# Altium schematic sheet Sheet2.SchDoc
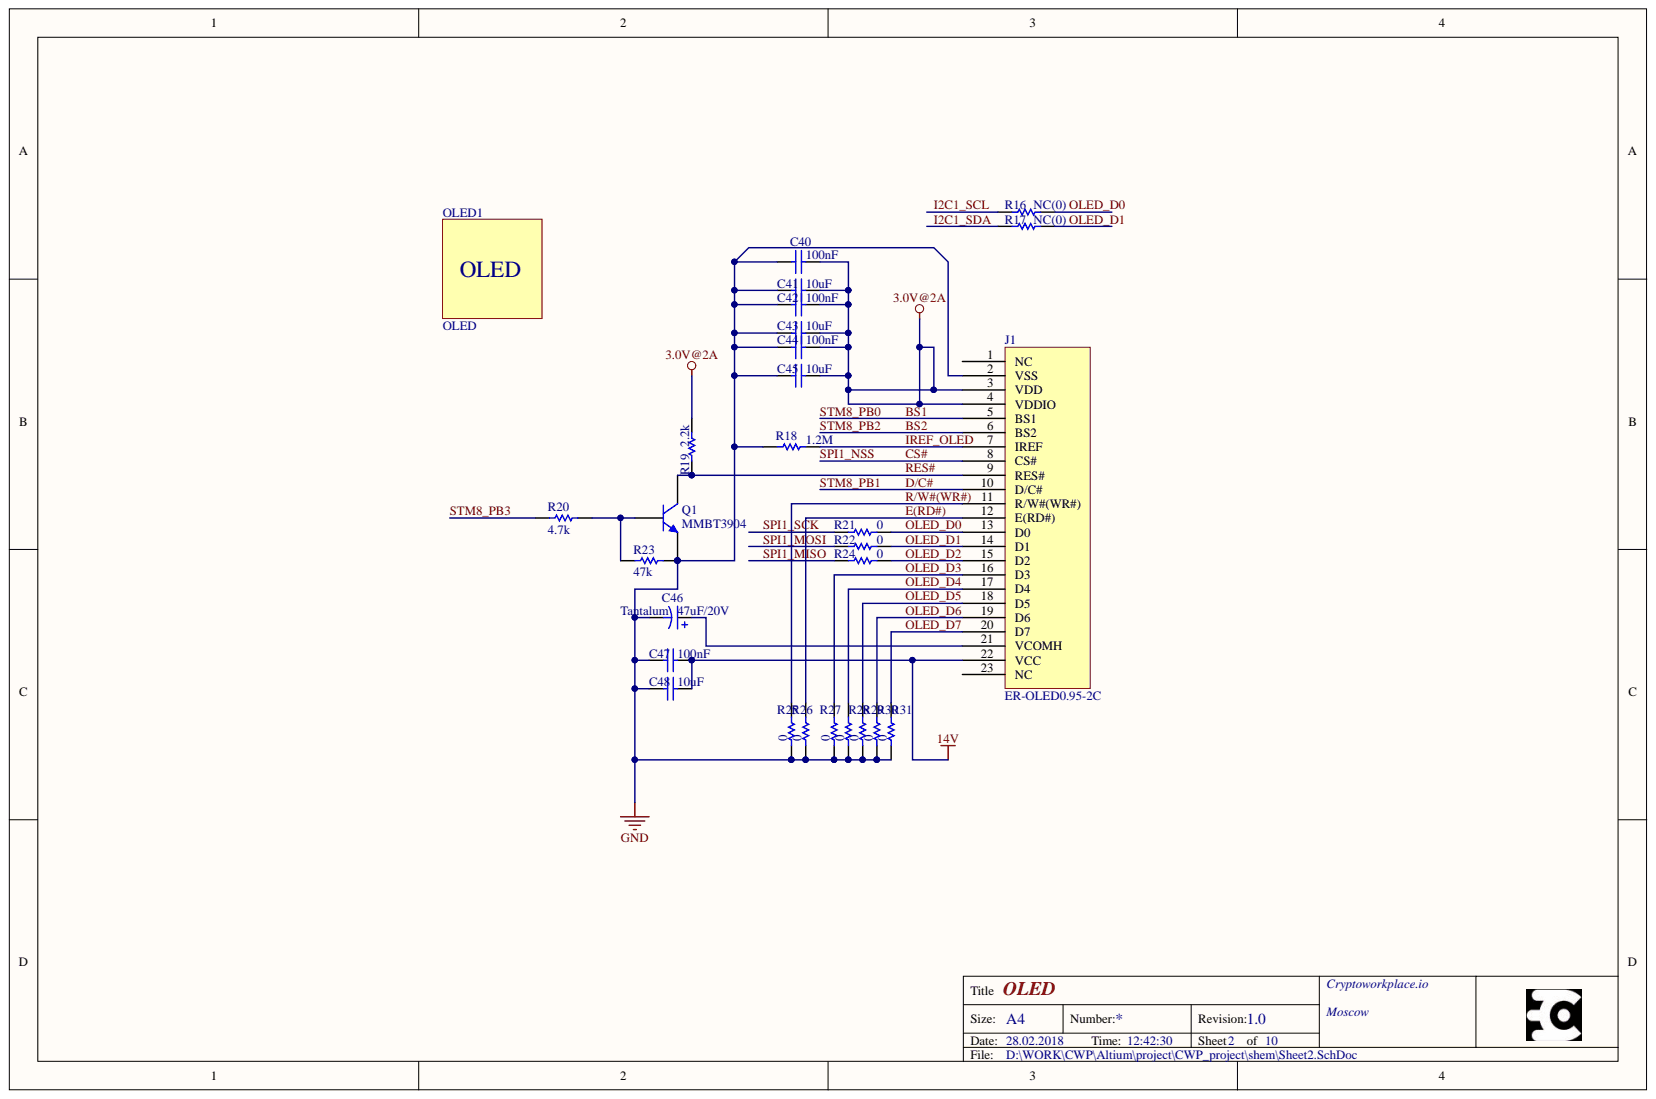
[diagram p0: Sheet2.SchDoc]

## Parts
C40  value 100nF  [0402(CAPC1005X06N)]  (hidden comment: 0402)
C41  value 10uF  [0603(CAPC1608X09N)]  (hidden comment: Cap)
C42  value 100nF  [0402(CAPC1005X06N)]  (hidden comment: 0402)
C43  value 10uF  [0603(CAPC1608X09N)]  (hidden comment: Cap)
C44  value 100nF  [0402(CAPC1005X06N)]  (hidden comment: 0402)
C45  value 10uF  [0603(CAPC1608X09N)]  (hidden comment: Cap)
C46  Tantalum  value 47uF/20V  [SMDDCAP]
C47  value 100nF  [0402(CAPC1005X06N)]  (hidden comment: 0402)
C48  value 10uF  [0603(CAPC1608X09N)]  (hidden comment: Cap)
J1  ER-OLED0.95-2C  [oled 23 pin con]
OLED1  OLED  [oled 0.96]
Q1  MMBT3904  [MMBT3904]
R16  value NC(0)  [0402(RESC1005X04N)]  (hidden comment: Res1)
R17  value NC(0)  [0402(RESC1005X04N)]  (hidden comment: Res1)
R18  value 1.2M  [0402(RESC1005X04N)]  (hidden comment: Res1)
R19  value 2.2k  [0402(RESC1005X04N)]  (hidden comment: Res1)
R20  value 4.7k  [0402(RESC1005X04N)]  (hidden comment: Res1)
R21  value 0  [0402(RESC1005X04N)]  (hidden comment: Res1)
R22  value 0  [0402(RESC1005X04N)]  (hidden comment: Res1)
R23  value 47k  [0402(RESC1005X04N)]  (hidden comment: Res1)
R24  value 0  [0402(RESC1005X04N)]  (hidden comment: Res1)
R25  value 0  [0402(RESC1005X04N)]  (hidden comment: Res1)
R26  value 0  [0402(RESC1005X04N)]  (hidden comment: Res1)
R27  value 0  [0402(RESC1005X04N)]  (hidden comment: Res1)
R28  value 0  [0402(RESC1005X04N)]  (hidden comment: Res1)
R29  value 0  [0402(RESC1005X04N)]  (hidden comment: Res1)
R30  value 0  [0402(RESC1005X04N)]  (hidden comment: Res1)
R31  value 0  [0402(RESC1005X04N)]  (hidden comment: Res1)

## Nets
3.0V@2A: C40.1 C41.1 C42.1 C43.1 C44.1 C45.1 J1.3(VDD) J1.4(VDDIO) R19.2
14V: C47.1 C48.1 J1.22(VCC)
GND: C40.2 C41.2 C42.2 C43.2 C44.2 C45.2 C46.2 C47.2 C48.2 J1.2(VSS) Q1.3 R18.1 R23.2 R25.2 R26.2 R27.2 R28.2 R29.2 R30.2 R31.2
BS1: J1.5(BS1)
BS2: J1.6(BS2)
CS#: J1.8(CS#)
D/C#: J1.10(D/C#)
E(RD#): J1.12(E(RD#)) R26.1
I2C1_SCL: R16.2
I2C1_SDA: R17.2
IREF_OLED: J1.7(IREF) R18.2
OLED_D0: J1.13(D0) R16.1 R21.2
OLED_D1: J1.14(D1) R17.1 R22.2
OLED_D2: J1.15(D2) R24.2
OLED_D3: J1.16(D3) R27.1
OLED_D4: J1.17(D4) R28.1
OLED_D5: J1.18(D5) R29.1
OLED_D6: J1.19(D6) R30.1
OLED_D7: J1.20(D7) R31.1
R/W#(WR#): J1.11(R/W#(WR#)) R25.1
RES#: J1.9(RES#) Q1.1 R19.1
SPI1_MISO: R24.1
SPI1_MOSI: R22.1
SPI1_SCK: R21.1
STM8_PB3: R20.1
NetC46_1: C46.1 J1.21(VCOMH)
NetQ1_2: Q1.2 R20.2 R23.1

Not connected: J1 1(NC) 23(NC)

## Library data of the parts
C40-C45 C47 C48 = Cap: Capacitor; Component Kind: Standard; LatestRevisionDate: 17-Jul-2002; LatestRevisionNote: Re-released for DXP Platform.; Library Name: Miscellaneous Devices.IntLib; PackageDescription: Radial Cap, Thru-Hole; 2 Leads; 0.3 in Pin Spacing; PackageReference: RAD-0.3; Published: 8-Jun-2000; Signal Integrity: Cap; Simulation: CAP; Publisher: Altium Limited
C46 = Cap Pol1: Polarized Capacitor (Radial); Component Kind: Standard; LatestRevisionDate: 17-Jul-2002; LatestRevisionNote: Re-released for DXP Platform.; Library Name: Miscellaneous Devices.IntLib; PackageDescription: Polarized Capacitor (Radial); 2 Leads; PackageReference: RB7.6-15; Published: 8-Jun-2000; Signal Integrity: Cap Pol; Simulation: CAP; Publisher: Altium Limited
J1 = ER-OLED0.95-2C: ER-OLED0.95-2C (OLED CONNECTOR); Component Kind: Standard; Library Name: OLED.SchLib
Q1 = NPN: Code_JEDEC: TO-226-AA; Component Kind: Standard; Description: MMBT3904; LatestRevisionDate: 17-Jul-2002; LatestRevisionNote: Re-released for DXP Platform.; Library Name: Miscellaneous Devices.IntLib; PackageDescription: TO, Flat Index; 3 In-Line, Axial Leads; Body Dia. 4.8mm; Leads 0.55 x 0.51 mm (max); PackageReference: TO-226-AA; Published: 8-Jun-2000; Signal Integrity: NPN; Simulation: NPN; Publisher: Altium Limited
R16-R31 = Res1: Resistor; Component Kind: Standard; LatestRevisionDate: 17-Jul-2002; LatestRevisionNote: Re-released for DXP Platform.; Library Name: Miscellaneous Devices.IntLib; PackageDescription: Axial Device, Thru-Hole; 2 Leads; 0.3 in Pin Spacing; PackageReference: AXIAL-0.3; Published: 8-Jun-2000; Signal Integrity: Res; Simulation: RESISTOR; Publisher: Altium Limited
OLED1 = OLED: Component Kind: Standard; Description: OLED; Library Name: OLED.SchLib
